# Altium schematic sheet SDEC945-xB_Audio.SchDoc(SDEC945-xB_Audio)
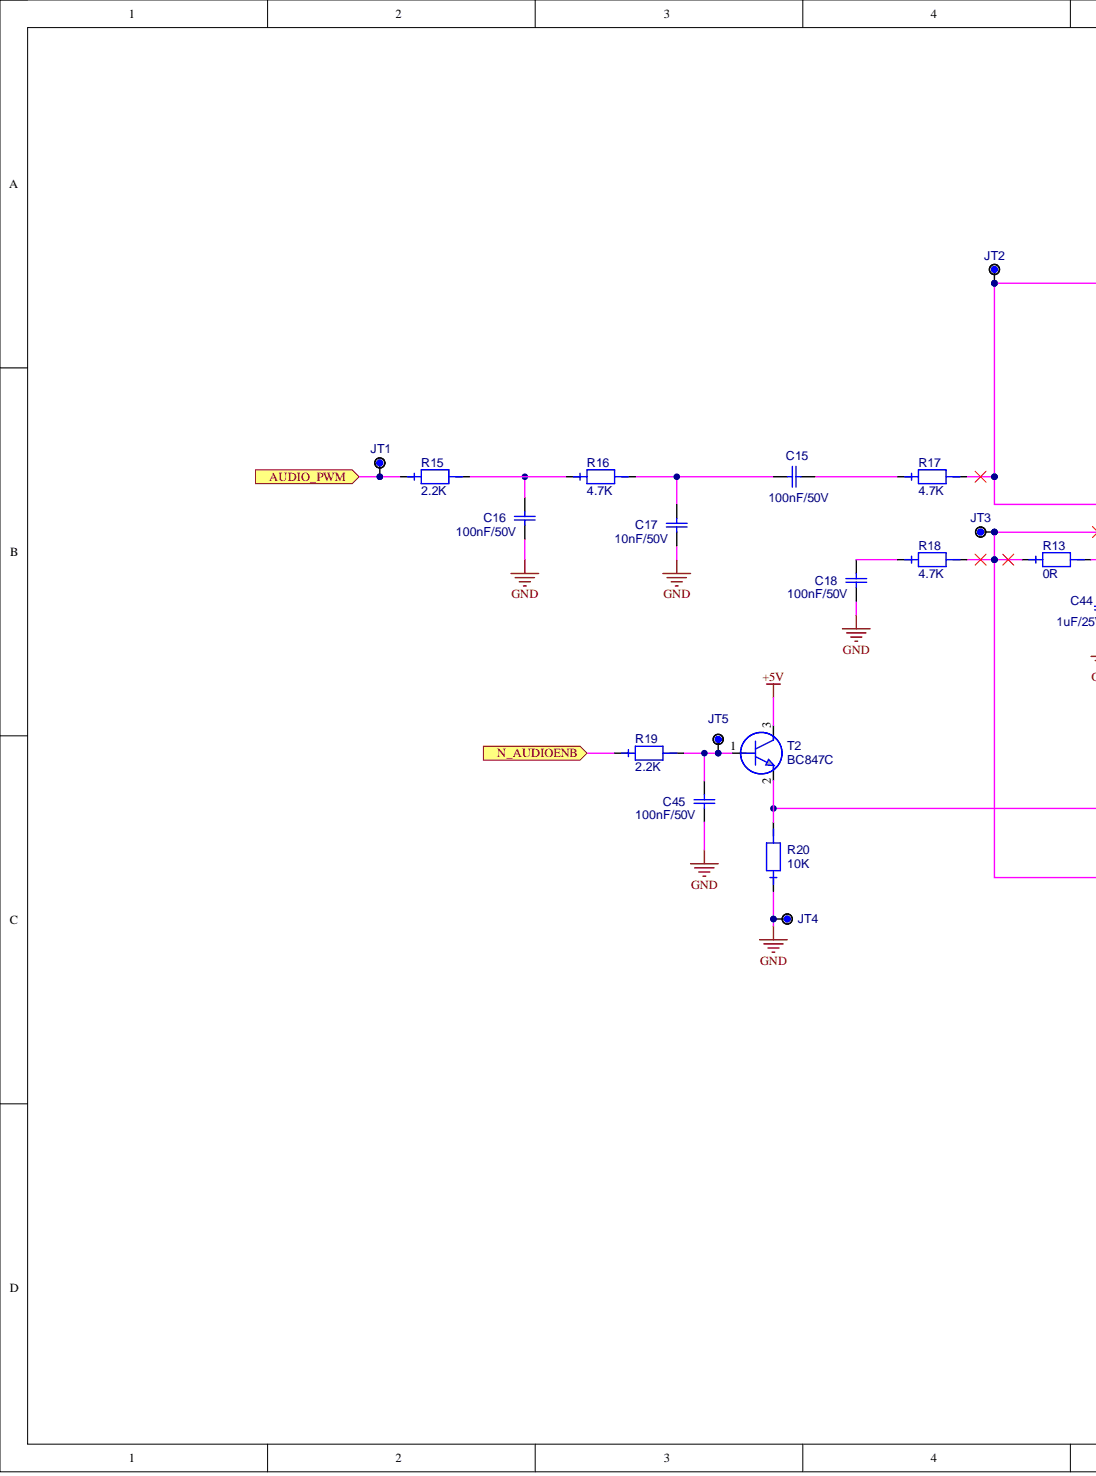
[diagram p0: SDEC945-xB_Audio.SchDoc, left]
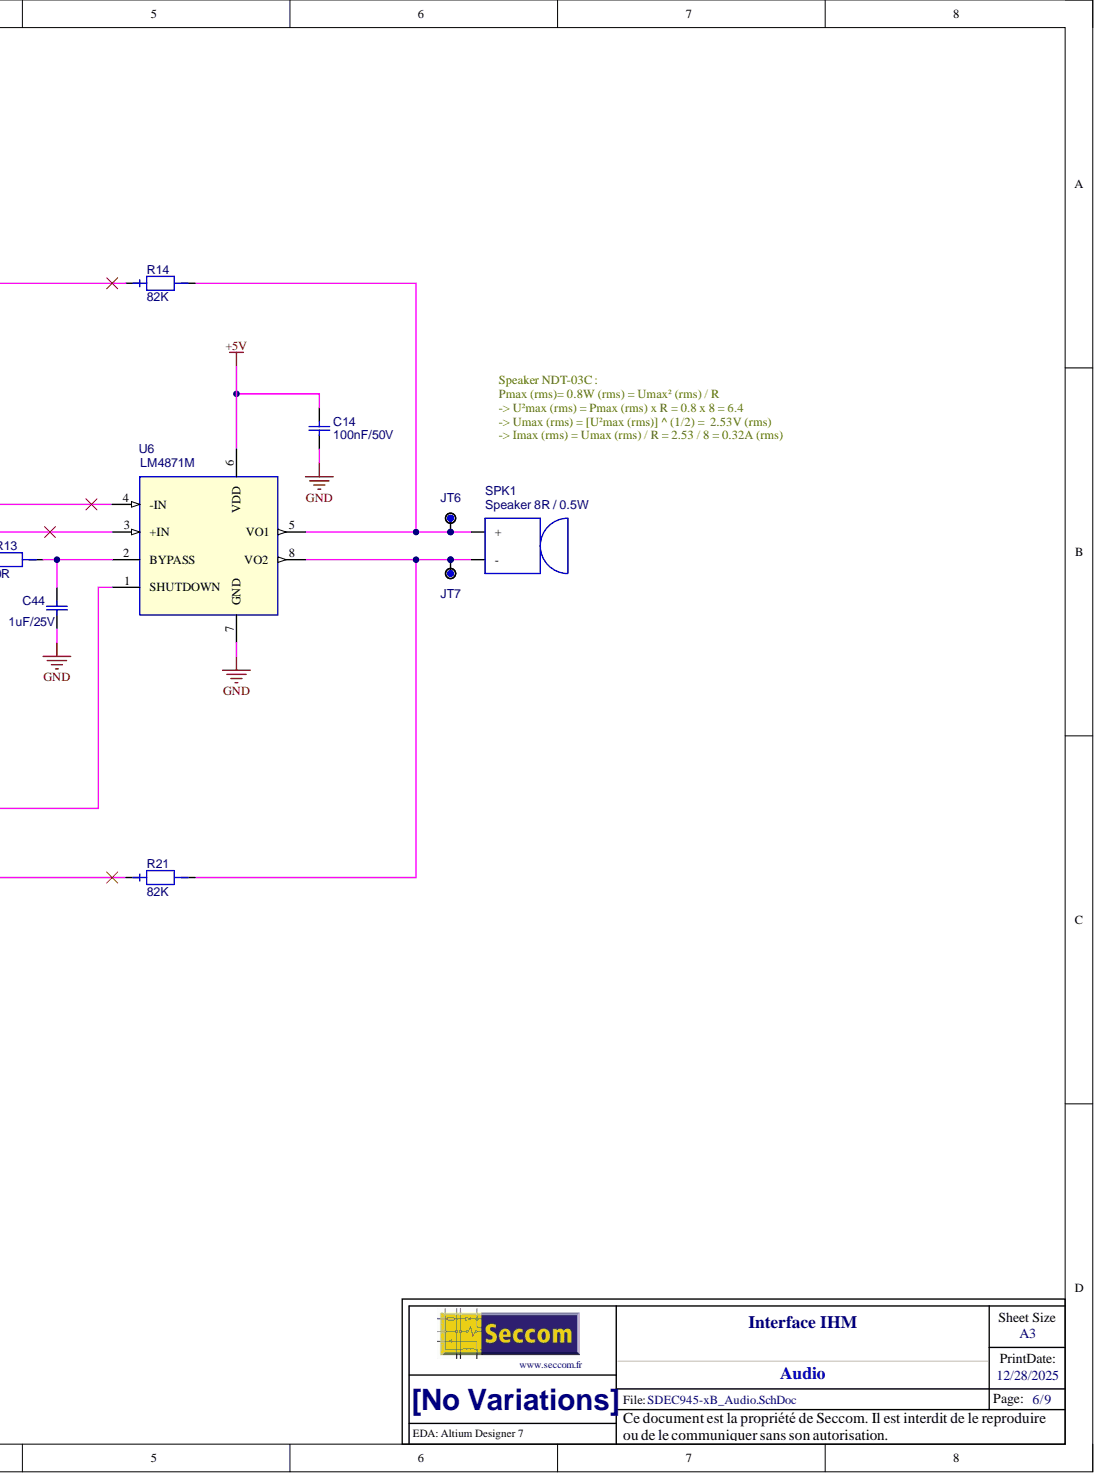
[diagram p1: SDEC945-xB_Audio.SchDoc, right]

## Parts
C14  value 100nF/50V  (hidden comment: C_0603_X7R_10%_100N-50V)
C15  value 100nF/50V  (hidden comment: C_0603_X7R_10%_100N-50V)
C16  value 100nF/50V  (hidden comment: C_0603_X7R_10%_100N-50V)
C17  value 10nF/50V  (hidden comment: C_0603_X7R_10%_10N-50V)
C18  value 100nF/50V  (hidden comment: C_0603_X7R_10%_100N-50V)
C44  value 1uF/25V  (hidden comment: C_0805_X5R_10%_1U-25V)
C45  value 100nF/50V  (hidden comment: C_0603_X7R_10%_100N-50V)
JT1  POINT_TEST_PAD_40MIL  (value not drawn)  (no BOM)
JT2  POINT_TEST_PAD_40MIL  (value not drawn)  (no BOM)
JT3  POINT_TEST_PAD_40MIL  (value not drawn)  (no BOM)
JT4  POINT_TEST_PAD_40MIL  (value not drawn)  (no BOM)
JT5  POINT_TEST_PAD_40MIL  (value not drawn)  (no BOM)
JT6  POINT_TEST_PAD_40MIL  (value not drawn)  (no BOM)
JT7  POINT_TEST_PAD_40MIL  (value not drawn)  (no BOM)
R13  value 0R  (hidden comment: RES_0603_1%_100PPM_0R)
R14  value 82K  (hidden comment: RES_0603_1%_100PPM_82K)
R15  value 2.2K  (hidden comment: RES_0603_1%_100PPM_2.2K)
R16  value 4.7K  (hidden comment: RES_0603_1%_100PPM_4.7K)
R17  value 4.7K  (hidden comment: RES_0603_1%_100PPM_4.7K)
R18  value 4.7K  (hidden comment: RES_0603_1%_100PPM_4.7K)
R19  value 2.2K  (hidden comment: RES_0603_1%_100PPM_2.2K)
R20  value 10K  (hidden comment: RES_0603_1%_100PPM_10K)
R21  value 82K  (hidden comment: RES_0603_1%_100PPM_82K)
SPK1  value Speaker 8R / 0.5W  (hidden comment: SPEAKER_8R_0.5W_NDT-03C)
T2  BC847C
U6  LM4871M

## Ports
AUDIO_PWM, N_AUDIOENB

## Nets
+5V: C14.2 T2.3 U6.6(VDD)
GND: C14.1 C16.1 C17.1 C18.1 C44.1 C45.1 JT4.1 R20.1 U6.7(GND)
AUDIO_PWM: JT1.1 R15.1 ; port AUDIO_PWM
N_AUDIOENB: R19.1 ; port N_AUDIOENB
NetC15_1: C15.1 R17.1
NetC15_2: C15.2 C17.2 R16.2
NetC16_2: C16.2 R15.2 R16.1
NetC18_2: C18.2 R18.1
NetC44_2: C44.2 R13.2 U6.2(BYPASS)
NetC45_2: C45.2 JT5.1 R19.2 T2.1
NetJT2_1: JT2.1 R14.1 R17.2 U6.4(-IN)
NetJT3_1: JT3.1 R13.1 R18.2 R21.1 U6.3(+IN)
NetJT6_1: JT6.1 R14.2 SPK1.1 U6.5(VO1)
NetJT7_1: JT7.1 R21.2 SPK1.2 U6.8(VO2)
NetR20_2: R20.2 T2.2 U6.1(SHUTDOWN)

## Library data of the parts
C14-C16 C18 C45 = C_0603_X7R_10%_100N-50V: Condensateur céramique, X7R, 10%, CMS 0603, 100nF/50V; Boitier: 0603; Coeff: 1; Creation: FB (29/02/12); Fabricant: Kemet; Ref Fab: C0603C104K5RAC; Remarque: {Remarque}; Component Kind: Standard; ComponentLink1Description: Datasheet; ComponentLink2Description: Datasheet Boitier; Library Name: SC94x.SchLib; Supplier 1: Farnell; Supplier Part Number 1: 1288255; ComponentLink1URL: O:\_Documentation\Datasheets\Kemet\Condos_Ceramic_CMS.pdf; ComponentLink2URL: o:\_Documentation\Datasheets\_BOITIERS\PASSIF_CMS\
C17 = C_0603_X7R_10%_10N-50V: Condensateur céramique, X7R, 10%, CMS 0603, 10nF/50V; Boitier: 0603; Coeff: 1; Creation: FB (01/03/12); Fabricant: Kemet; Ref Fab: C0603C103K5RAC; Remarque: {Remarque}; Component Kind: Standard; ComponentLink1Description: Datasheet; ComponentLink2Description: Datasheet Boitier; Library Name: SC94x.SchLib; Supplier 1: Farnell; Supplier 2: RadioSpares; Supplier Part Number 1: 1414609; Supplier Part Number 2: 2644595; ComponentLink1URL: O:\_Documentation\Datasheets\Kemet\Condos_Ceramic_CMS.pdf; ComponentLink2URL: o:\_Documentation\Datasheets\_BOITIERS\PASSIF_CMS\
C44 = C_0805_X5R_10%_1U-25V: Condensateur céramique, X5R, 10%, CMS 0805; Boitier: 0805; Coeff: 1; Creation: FB (07/03/12); Fabricant: AVX; Ref Fab: 08053D105KAT2A; Reference: 001834/00; Remarque: {Remarque}; Component Kind: Standard; ComponentLink1Description: Datasheet; ComponentLink2Description: Datasheet Boitier; Supplier 1: Farnell; Supplier 2: Radiospares; Supplier Part Number 1: 1327695; Supplier Part Number 2: 6983409; ComponentLink1URL: O:\_Documentation\Datasheets\AVX\Condensateurs_CMS_Ceramic_X5R.pdf; ComponentLink2URL: o:\_Documentation\Datasheets\_Boitiers\PASSIF_CMS\RC_0805_(2x1,25)_(Schneider).pdf; Library Name: CAPACITIFS_CMS.SchLib
JT1-JT7 = POINT_TEST_PAD_40MIL: Point de test sur CI, pad de diamètre 1.016mm (40mils); Coeff: 1; Creation: FB (04/10/12); Remarque: {Remarque}; ComponentLink1Description: Datasheet; ComponentLink2Description: Datasheet Boitier; Value: POINT_TEST; Library Name: CONN_PICOT.SchLib
R13 = RES_0603_1%_100PPM_0R: Résistance, 0.100W, 1%, 100ppm, 75V, 0R; Boitier: 0603; Coeff: 1; Creation: FB (04/07/12); Fabricant: Vishay; Ref Fab: CRCW06030000Z0EA; Remarque: {Remarque}; Component Kind: Standard; ComponentLink1Description: Datasheet; ComponentLink2Description: Datasheet Boitier; Library Name: SC94x.SchLib; Supplier 1: Farnell; Supplier Part Number 1: 1469739; ComponentLink1URL: O:\_Documentation\Datasheets\Vishay\Resistances_D-CRCWe3.pdf; ComponentLink2URL: o:\_Documentation\Datasheets\_BOITIERS\PASSIF_CMS\
R14 R21 = RES_0603_1%_100PPM_82K: Résistance, 0.100W, 1%, 100ppm, 75V, 82K; Boitier: 0603; Coeff: 1; Creation: FB (01/03/12); Fabricant: Vishay; Ref Fab: CRCW060382K0FKEA; Remarque: {Remarque}; Component Kind: Standard; ComponentLink1Description: Datasheet; ComponentLink2Description: Datasheet Boitier; Library Name: SC94x.SchLib; Supplier 1: Farnell; Supplier 2: RadioSpares; Supplier Part Number 1: 1152140; Supplier Part Number 2: 6790737; ComponentLink1URL: O:\_Documentation\Datasheets\Vishay\Resistances_D-CRCWe3.pdf; ComponentLink2URL: o:\_Documentation\Datasheets\_BOITIERS\PASSIF_CMS\
R15 R19 = RES_0603_1%_100PPM_2.2K: Résistance, 0.100W, 1%, 100ppm, 75V, 2.2K; Boitier: 0603; Coeff: 1; Creation: JPL (05/07/12); Fabricant: Vishay; Ref Fab: CRCW06032K20FKEA; Remarque: {Remarque}; Component Kind: Standard; ComponentLink1Description: Datasheet; ComponentLink2Description: Datasheet Boitier; Library Name: SC94x.SchLib; Supplier 1: Farnell; Supplier 2: RadioSpares; Supplier Part Number 1: 1469765; Supplier Part Number 2: 6790112; ComponentLink1URL: O:\_Documentation\Datasheets\Vishay\Resistances_D-CRCWe3.pdf; ComponentLink2URL: o:\_Documentation\Datasheets\_BOITIERS\PASSIF_CMS\
R16-R18 = RES_0603_1%_100PPM_4.7K: Résistance, 0.100W, 1%, 100ppm, 75V, 4.7K; Boitier: 0603; Coeff: 1; Creation: JPL (05/07/12); Fabricant: Vishay; Ref Fab: CRCW06034K70FKEA; Remarque: {Remarque}; Component Kind: Standard; ComponentLink1Description: Datasheet; ComponentLink2Description: Datasheet Boitier; Library Name: SC94x.SchLib; Supplier 1: Farnell; Supplier 2: RadioSpares; Supplier Part Number 1: 1469807; Supplier Part Number 2: 6790484; ComponentLink1URL: O:\_Documentation\Datasheets\Vishay\Resistances_D-CRCWe3.pdf; ComponentLink2URL: o:\_Documentation\Datasheets\_BOITIERS\PASSIF_CMS\
R20 = RES_0603_1%_100PPM_10K: Résistance, 0.100W, 1%, 100ppm, 75V, 10K; Boitier: 0603; Coeff: 1; Creation: JPL (05/07/12); Fabricant: Vishay; Ref Fab: CRCW060310K0FKEA; Remarque: {Remarque}; Component Kind: Standard; ComponentLink1Description: Datasheet; ComponentLink2Description: Datasheet Boitier; Library Name: SC94x.SchLib; Supplier 1: Farnell; Supplier 2: RadioSpares; Supplier Part Number 1: 1469748; Supplier Part Number 2: 6789667; ComponentLink1URL: O:\_Documentation\Datasheets\Vishay\Resistances_D-CRCWe3.pdf; ComponentLink2URL: o:\_Documentation\Datasheets\_BOITIERS\PASSIF_CMS\
SPK1 = SPEAKER_8R_0.5W_NDT-03C: Speaker dynamique, impedance 8R, Pnom=0.5W, Pmax=0.8W, Fres=800Hz, 90dBA, -30/+85°C, CMS; Boitier: 15x15x4mm; Coeff: 1; Creation: FB (29/02/12); Fabricant: Star-Micronics; Remarque: {Remarque}; Component Kind: Standard; ComponentLink1Description: Datasheet; ComponentLink2Description: Datasheet Boitier; Library Name: SC94x.SchLib; Ref Fab: NDT-03C; Supplier 1: Farnell; Supplier Part Number 1: 1299760; ComponentLink1URL: O:\_Documentation\Datasheets\Star_Micronics\NDT-03C_Datasheet.pdf; ComponentLink2URL: O:\_Documentation\Datasheets\Star_Micronics\NDT-03C_Footprint.pdf
T2 = BC847C: Transistor NPN, Vce=50V, Ic=0.1A, hfe=420~800, 0.25W, CMS; Boitier: SOT23_3L; Coeff: 1; Creation: GO (08/07/05); Fabricant: NXP; Reference: 000329/00; Remarque: Complementaires aux BC856-860; Verification: LJ (21/07/05); Component Kind: Standard; ComponentLink1Description: Datasheet; ComponentLink2Description: Datasheet Boitier; Library Name: TRANSISTOR.SchLib; Ref Fab: BC847C; Supplier 1: Farnell; Supplier Part Number 1: 1081235; Value: BC847C; ComponentLink1URL: O:\_Documentation\Datasheets\NXP\BC847.pdf; ComponentLink2URL: o:\_Documentation\Datasheets\_BOITIERS\ACTIF_CMS\SOT\SOT_23_3P_(Schneider).PDF
U6 = LM4871M: Amplificateur audio avec shutdown, 1.5W/8ohm, Vdd=2~5.5V, -40/+125°C, CMS; Boitier: SOIC 8; Coeff: 1; Creation: PDP (11/07/12); Fabricant: National Semiconductor; Ref Fab: LM4871M/NOPB; Remarque: {Remarque}; Verification: FB (11/09/12); Component Kind: Standard; ComponentLink1Description: Datasheet; ComponentLink2Description: Datasheet Boitier; Library Name: SC94x.SchLib; Supplier 1: Farnell; Supplier 2: Mouser; Supplier Part Number 1: 1468873; Supplier Part Number 2: 926-LM4871M/NOPB; Value: LM4871M; ComponentLink1URL: o:\_Documentation\Datasheets\National_SemiConductors\LM4871.pdf; ComponentLink2URL: o:\_Documentation\Datasheets\_Boitiers\ACTIF_CMS\
